# Altium schematic sheet 2.WIZ750SR_EVB_PWR_USB.SchDoc
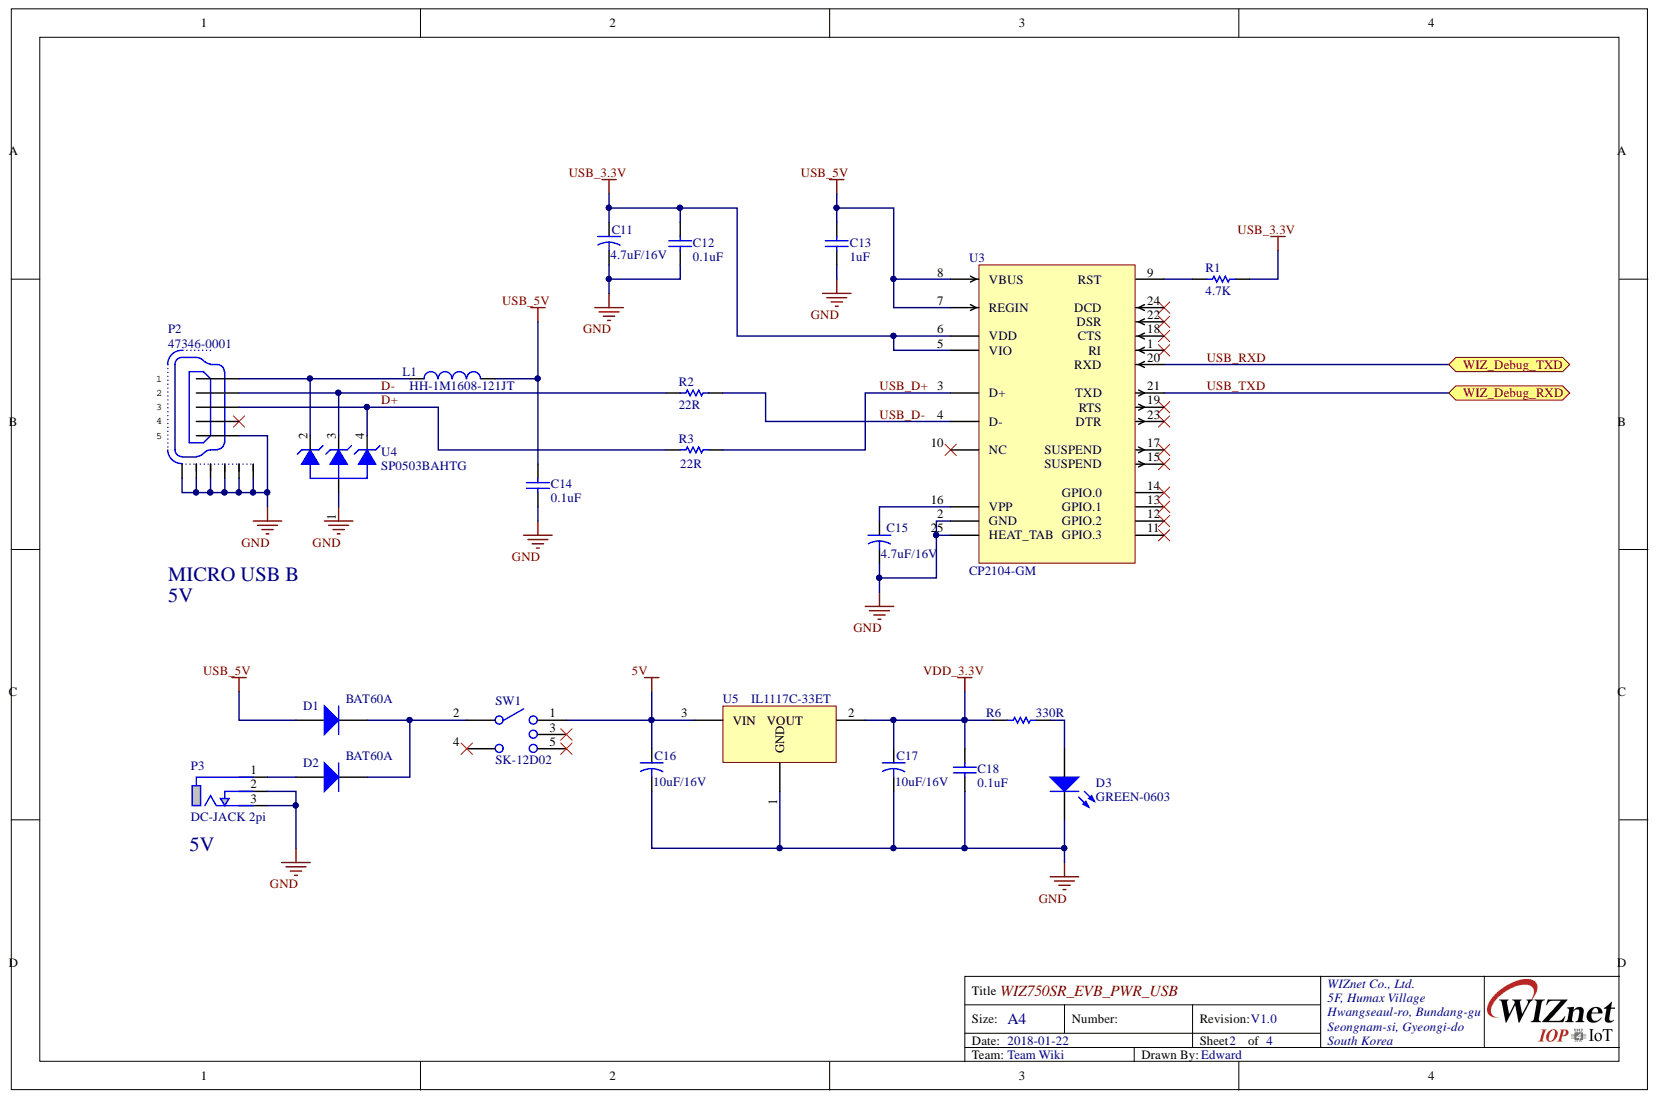
[diagram p0: 2.WIZ750SR_EVB_PWR_USB.SchDoc]

## Parts
C11  4.7uF/16V  [CAP-A Size]
C12  0.1uF  [CAP-0603]
C13  1uF  [CAP-0603]
C14  0.1uF  [CAP-0603]
C15  4.7uF/16V  [CAP-A Size]
C16  10uF/16V  [CAP-A Size]
C17  10uF/16V  [CAP-A Size]
C18  0.1uF  [CAP-0603]
D1  BAT60A  [D-BAT60A/SOD-323]
D2  BAT60A  [D-BAT60A/SOD-323]
D3  GREEN-0603  [LED-0603-RED]
L1  HH-1M1608-121JT  [IND-0603]
P2  47346-0001  [USB-MICRO-B(SMD)]
P3  DC-JACK 2pi  [DC-JACK 2pi]
R1  4.7K  [RES-0603]
R2  22R  [RES-0603]
R3  22R  [RES-0603]
R6  330R  [RES-0603]
SW1  SK-12D02  [SK-12D02]
U3  CP2104-GM  [CP2104_QFN24_PAD]
U4  SP0503BAHTG  [SP0503BAHTG]
U5  IL1117C-33ET  [IL1117C-33ET]

## Ports
WIZ_DEBUG_RXD, WIZ_DEBUG_TXD

## Nets
5V: C16.1 SW1.1 U5.3
GND: C11.2 C12.1 C13.1 C14.1 C15.2 C16.2 C17.2 C18.1 D3.2 P2.5 P2.6 P2.7 P2.8 P2.9 P2.10 P2.11 P3.2 P3.3 U3.2(GND) U3.25(HEAT_TAB) U4.1 U5.1
USB_3.3V: C11.1 C12.2 R1.2 U3.5(VIO) U3.6(VDD)
USB_5V: C13.2 C14.2 D1.2 L1.2 U3.7(REGIN) U3.8(VBUS)
VDD_3.3V: C17.1 C18.2 R6.1 U5.2
D+: P2.3 R3.1 U4.4
D-: P2.2 R2.1 U4.3
USB_D+: R3.2 U3.3(D+)
USB_D-: R2.2 U3.4(D-)
USB_RXD: U3.20 ; port WIZ_DEBUG_TXD
USB_TXD: U3.21 ; port WIZ_DEBUG_RXD
NetC15_1: C15.1 U3.16(VPP)
NetD1_1: D1.1 D2.1 SW1.2
NetD2_2: D2.2 P3.1
NetD3_1: D3.1 R6.2
NetL1_1: L1.1 P2.1 U4.2
NetR1_1: R1.1 U3.9

Not connected: P2 4; SW1 3 4 5; U3 1 10(NC) 11 12 13 14 15 17 18 19 22 23 24

## Library data of the parts
C11 C15-C17 = CAP-A Size: Tantla CAP A Size EIA/IECQ 3216; Component Kind: Standard; Library Name: Scott_lib.IntLib
C12-C14 C18 = CAP-0603: Ceramic CAP 0603(1608); Component Kind: Standard; Library Name: Scott_lib.IntLib
D1 D2 = DIODE-BAT60A: Silicon Schottky Diode; Component Kind: Standard; Library Name: Scott_lib.IntLib
D3 = LED-0603: Component Kind: Standard; Description: LED-0603; Library Name: Scott_lib.IntLib
L1 = IND-0603: Inductor 0603(1608); Component Kind: Standard; Library Name: WIZ750SR.IntLib
P2 = 47346-0001: MICRO USB B Type; Component Kind: Standard; Library Name: Edward.SchLib
R1-R3 R6 = RES-0603: Component Kind: Standard; Description: RES-0603; Library Name: Scott_lib.IntLib
SW1 = SK-12D02: 3 Port SW , SPDT ON-ON , 50V, 0.3A; Component Kind: Standard; Library Name: Edward.IntLib
U3 = CP2104-GM: USB to UART Bridge, SiliconLabs; Component Kind: Standard; RefDes: RefDes; Type: Type; Value: Value; Library Name: Edward.IntLib
U4 = SP0503BAHTG: Little Fuse, Silicon Protection Array Products; Component Kind: Standard; Library Name: Edward.IntLib
U5 = IL1117C-33ET: 1A, 3.3V; Component Kind: Standard; Library Name: Edward.IntLib
P3 = DC-JACK 2pi: Component Kind: Standard; Description: DC-JACK 2pi; Library Name: Scott_lib.IntLib
